# Altium schematic sheet Э3("All Documents",Physical)
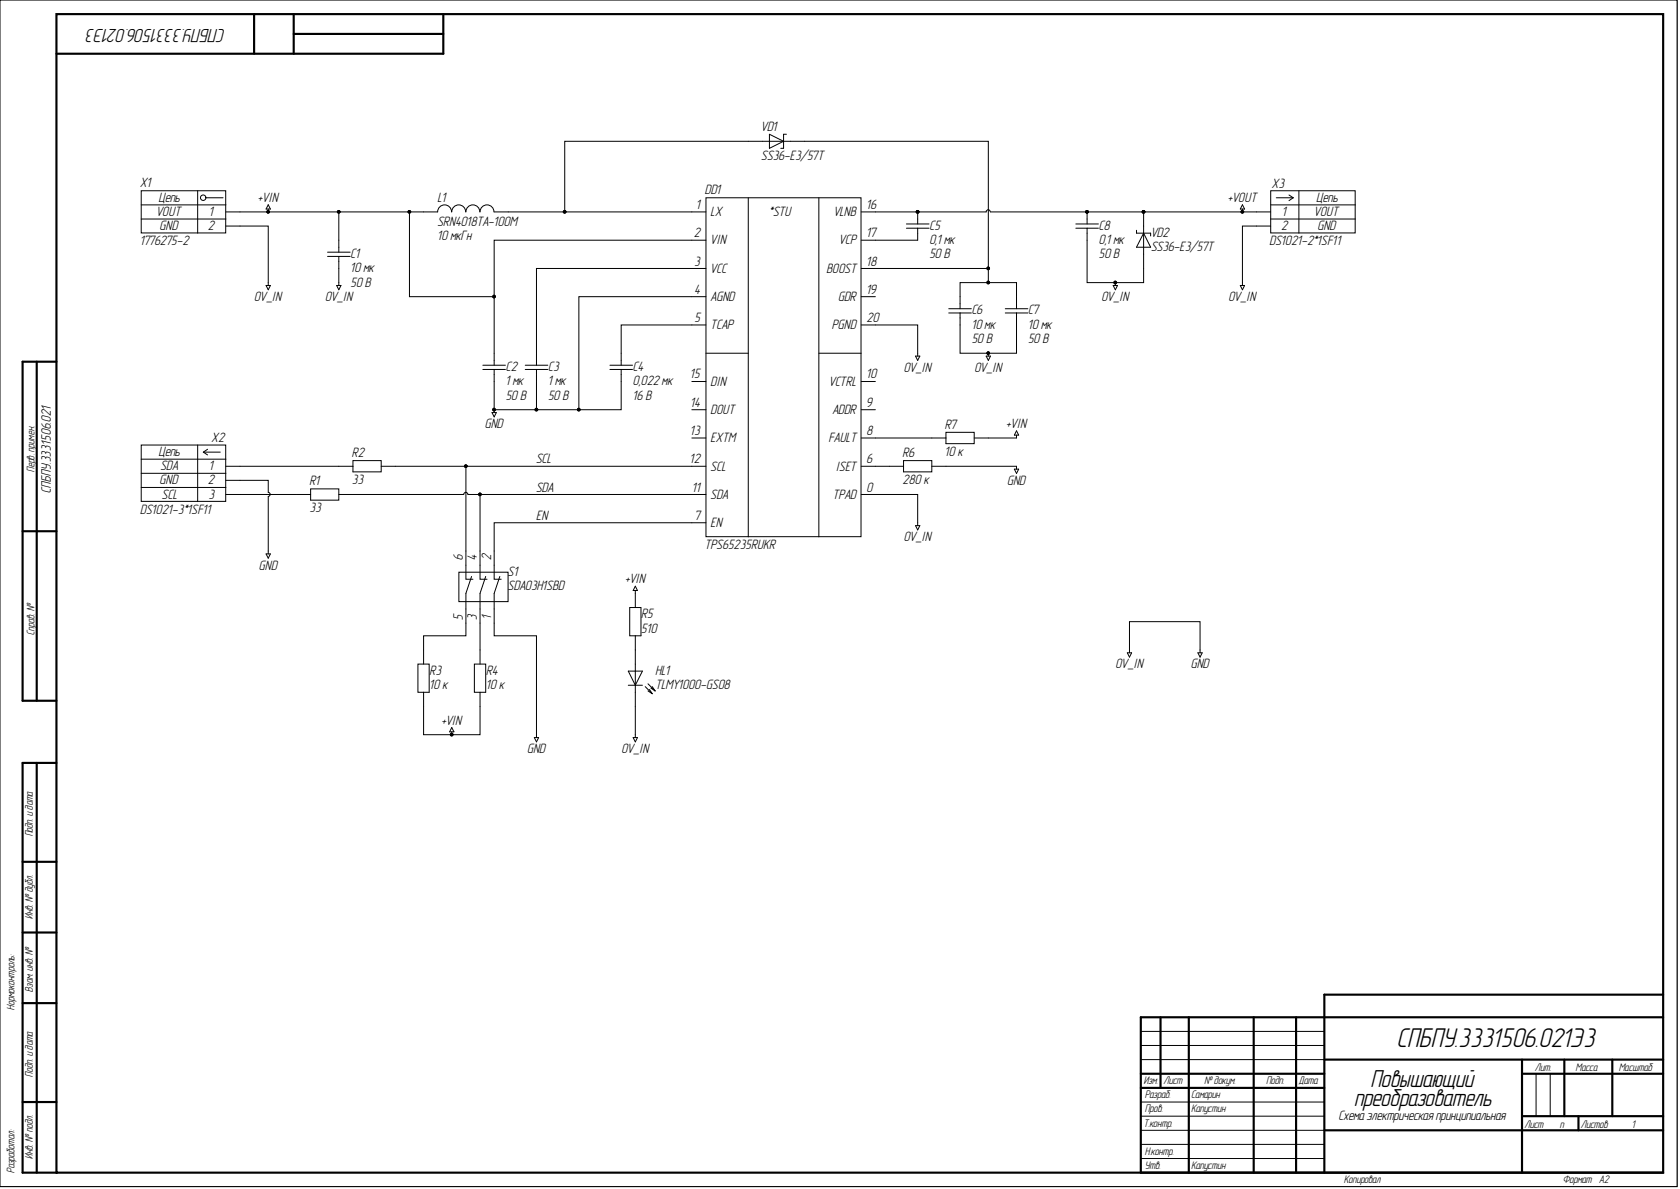
[diagram p0: Э3("All Documents",Physical)]

## Parts
C1  10 мк  Номинальное напряжение: 50 В  [C1206]
C2  1 мк  [C0603]
C3  1 мк  Номинальное напряжение: 50 В  [C0603]
C4  0,022 мк  Номинальное напряжение: 16 В  [C0201]
C5  0,1 мк  Номинальное напряжение: 50 В  [C0603]
C6  10 мк  Номинальное напряжение: 50 В  [C1206]
C7  10 мк  Номинальное напряжение: 50 В  [C1206]
C8  0,1 мк  Номинальное напряжение: 50 В  [C0603]
DD1  TPS65235RUKR  [QFN-20]
HL1  TLMY1000-GS08  [HL0603]
L1  SRN4018TA-100M  Номинал: 10 мкГн  [SRN-2-400X400X180]
R1  33  [R0603]
R2  33  [R0603]
R3  10 к  [R0603]
R4  10 к  [R0603]
R5  510  [R0603]
R6  280 к  [R0603]
R7  10 к  [R0603]
S1  SDA03H1SBD  [DIP-SWITCH-03]
VD1  SS36-E3/57T  [DO-214AB]
VD2  SS36-E3/57T  [DO-214AB]
X1  1776275-2  Contact_2: GND  Contact_1: VOUT  [1776275-2]
X2  DS1021-3*1SF11  Contact_3: SCL  Contact_2: GND  Contact_1: SDA  [pls-2,54-3]
X3  DS1021-2*1SF11  Contact_2: GND  Contact_1: VOUT  [pls-2,54]

## Nets
0V_IN: C1.2 C2.2 C3.2 C4.2 C6.2 C7.2 C8.2 DD1.0(TPAD) DD1.4(AGND) DD1.20(PGND) HL1.1 R6.2 S1.1 VD2.2 X1.2 X2.2 X3.2
+VIN: C1.1 C2.1 DD1.2(VIN) L1.1 R3.1 R4.1 R5.2 R7.2 X1.1
+VOUT: C5.1 C8.1 DD1.16(VLNB) VD2.1 X3.1
EN: DD1.7(EN) S1.2
SCL: DD1.12(SCL) R2.2 S1.6
SDA: DD1.11(SDA) R1.2 S1.4
NetC3_1: C3.1 DD1.3(VCC)
NetC4_1: C4.1 DD1.5(TCAP)
NetC5_2: C5.2 DD1.17(VCP)
NetC6_1: C6.1 C7.1 DD1.18(BOOST) VD1.1
NetDD1_1: DD1.1(LX) L1.2 VD1.2
NetDD1_6: DD1.6(ISET) R6.1
NetDD1_8: DD1.8(FAULT) R7.1
NetDD1_9: DD1.9(ADDR)
NetDD1_10: DD1.10(VCTRL)
NetDD1_13: DD1.13(EXTM)
NetDD1_14: DD1.14(DOUT)
NetDD1_15: DD1.15(DIN)
NetDD1_19: DD1.19(GDR)
NetHL1_2: HL1.2 R5.1
NetR1_1: R1.1 X2.3
NetR2_1: R2.1 X2.1
NetR3_2: R3.2 S1.5
NetR4_2: R4.2 S1.3

## Library data of the parts
C1 C6 C7 = c0094: =Описание; Фамилия: Самарин; Температурный коэффициент емкости: X5R; Рабочие температуры: от –55 °С до +85 °С; Производитель: YAGEO Corp.; Отклонение: ±10 %; Описание: Конденсатор чип 1206 10 мкФ 50 В ±10 %; Наименование: CC1206KKX5R9BB106; Корпус: 1206; Database Table Name: Конденсаторы$; Номинал: 10 мк; Напряжение: 50 В; ID: c0094; HelpURL: https://www.yageo.com/upload/media/product/productsearch/datasheet/mlcc/UPY-GPHC_X5R_4V-to-50V_26.pdf; Description: Конденсатор чип 1206 10 мкФ 50 В ±10 %; Component Kind: Standard; Library Name: Database_Students.DbLib
C2 = c0023: =Описание; Фамилия: Самарин; Рабочие температуры: от -55 °С до +125 °С; Производитель: YAGEO Corp.; Отклонение: ±10 %; Описание: Конденсатор чип 0603 1 мкФ 50 В ±10 %; Номинальное напряжение: 50 В; Наименование: CC0603KPX5R9BB105; Корпус: 0603; Database Table Name: Конденсаторы$; Номинал: 1 мк; Напряжение: 50 В; ID: c0023; HelpURL: https://www.yageo.com/upload/media/product/productsearch/datasheet/mlcc/UPY-GPHC_X5R_4V-to-50V_26.pdf; Description: Конденсатор чип 0603 1 мкФ 50 В ±10 %; Component Kind: Standard; Library Name: Database_Students.DbLib
C3 = c0023: =Описание; Фамилия: Самарин; Рабочие температуры: от -55 °С до +125 °С; Производитель: YAGEO Corp.; Отклонение: ±10 %; Описание: Конденсатор чип 0603 1 мкФ 50 В ±10 %; Наименование: CC0603KPX5R9BB105; Корпус: 0603; Database Table Name: Конденсаторы$; Номинал: 1 мк; Напряжение: 50 В; ID: c0023; HelpURL: https://www.yageo.com/upload/media/product/productsearch/datasheet/mlcc/UPY-GPHC_X5R_4V-to-50V_26.pdf; Description: Конденсатор чип 0603 1 мкФ 50 В ±10 %; Component Kind: Standard; Library Name: Database_Students.DbLib
C4 = c0015: =Описание; Фамилия: Жернаков; Рабочие температуры: от -55 °С до +85 °С; Производитель: YAGEO Corp.; Отклонение: ±10 %; Описание: Конденсатор чип 0201 0,022 мкФ 16 В ±10 %; Наименование: CC0201KRX5R7BB223; Корпус: 0201; Database Table Name: Конденсаторы$; Номинал: 0,022 мк; Напряжение: 16 В; ID: c0015; HelpURL: https://www.yageo.com/upload/media/product/productsearch/datasheet/mlcc/UPY-GPHC_X5R_4V-to-50V_26.pdf; Description: Конденсатор чип 0201 0,022 мкФ 16 В ±10 %; Component Kind: Standard; Library Name: Database_Students.DbLib
C5 C8 = c0018: =Описание; Фамилия: Ларионов; Рабочие температуры: от -55 °С до +125 °С; Производитель: YAGEO Corp.; Отклонение: ±5 %; Описание: Конденсатор чип 0603 0,1 мкФ 50 В ±5 %; Наименование: CC0603JRX7R9BB104; Корпус: 0603; Database Table Name: Конденсаторы$; Номинал: 0,1 мк; Напряжение: 50 В; ID: c0018; HelpURL: https://www.yageo.com/upload/media/product/products/datasheet/mlcc/UPY-GPHC_X7R_6.3V-to-50V_20.pdf; Description: Конденсатор чип 0603 0,1 мкФ 50 В ±5 %; Component Kind: Standard; Library Name: Database_Students.DbLib
DD1 = dd0005: =Описание; Частота переключения: до 1 МГц; Фамилия: Самарин; Рабочие температуры: от -40 °С до +85 °С; Производитель: Texas Instruments; Описание: Повышающий преобразователь напряжения 20 В и 3 А; Коммутируемый ток: до 1 А; Выходное напряжение: от 11 В до 20 В; Входное напряжение: от 4,5 В до 20 В; Database Table Name: Преобразователи напряжения$; Наименование: TPS65235RUKR; Корпус: QFN-20; ID: dd0005; HelpURL: https://www.ti.com/product/TPS65235; Description: Повышающий преобразователь напряжения 20 В и 3 А; Component Kind: Standard; Library Name: Database_Students.DbLib
HL1 = hl0003: =Описание; Цвет: Yellow; Фамилия: Ларионов; Рассеиваемая мощность: 40 мВт; Рабочие температуры: от -40 °С до +100 °С; Производитель: Vishay Intertechnology, Inc.; Описание: Светодиод чип 0603 желтый; Номинальный прямой ток: 15 мА; Номинальное падение напряжения: 1,8 В; Номинальная сила света: 7,5 мкд; Номинальная длина волны: 588 нм; Корпус: 0603; Database Table Name: Светодиоды$; Наименование: TLMY1000-GS08; ID: hl0003; HelpURL: https://www.vishay.com/leds/list/product-83172/; Description: Светодиод чип 0603 желтый; Component Kind: Standard; Library Name: Database_Students.DbLib
L1 = l0003: =Описание; Фамилия: Самарин; Ток насыщения: 1,5 А; Резонансная частота: 35 МГц; Рабочие температуры: от –55 °С до +125 °С; Рабочее напряжение: не указано; Производитель: Bourns, Inc.; Отклонение: ±20 %; Описание: Катушка индуктивности 10 мкГн ±20 %; Номинальное активное сопротивление: 150 мОм; Корпус: 4,0 x 4,0 x 1,8; Database Table Name: Катушки индуктивности$; Номинальный ток (при нагреве на 20 °С/40 °С): 1,5 А; Наименование: SRN4018TA-100M; Максимальное активное сопротивление: не указано; Индуктивность: 10 мкГн; ID: l0003; HelpURL: https://www.bourns.com/products/magnetic-products/power-inductors-aec-q200-compliant/product/SRN4018TA; Description: Катушка индуктивности 10 мкГн ±20 %; Component Kind: Standard; Library Name: Database_Students.DbLib
R1 R2 = r0005: =Описание; Фамилия: Козлов; Рабочие температуры: от -55 °С до +155 °С; Производитель: YAGEO Corp.; Отклонение: ±1 %; Описание: Резистор чип 0603 33 Ом ±1 % 0,1 Вт; Номинальный ток: 1 А; Номинальное напряжение: 75 В; Наименование: AA0603FR-0733RL; Корпус: 0603; Database Table Name: Резисторы$; Номинал: 33; ID: r0005; HelpURL: https://www.yageo.com/upload/media/product/productsearch/datasheet/rchip/PYu-AA_51_RoHS_L_3.pdf; Description: Резистор чип 0603 33 Ом ±1 % 0,1 Вт; Component Kind: Standard; Library Name: Database_Students.DbLib
R3 R4 R7 = r0022: =Описание; Фамилия: Самарин; Рабочие температуры: от -55 °С до +155 °С; Производитель: YAGEO Corp.; Отклонение: ±1 %; Описание: Резистор чип 0603 10 кОм ±1 % 0,1 Вт; Номинальный ток: 1 А; Номинальное напряжение: 75 В; Наименование: RC0603FR-0710KL; Корпус: 0603; Database Table Name: Резисторы$; Номинал: 10 к; ID: r0022; HelpURL: https://www.yageo.com/upload/media/product/productsearch/datasheet/rchip/PYu-RC_Group_51_RoHS_L_11.pdf; Description: Резистор чип 0603 10 кОм ±1 % 0,1 Вт; Component Kind: Standard; Library Name: Database_Students.DbLib
R5 = r0011: =Описание; Фамилия: Самарин; Рабочие температуры: от -55 °С до +155 °С; Производитель: YAGEO Corp.; Отклонение: ±1 %; Описание: Резистор чип 0603 510 Ом ±1 % 0,1 Вт; Номинальный ток: 1 А; Номинальное напряжение: 75 В; Наименование: RC0603FR-07510RL; Корпус: 0603; Database Table Name: Резисторы$; Номинал: 510; ID: r0011; HelpURL: https://www.yageo.com/upload/media/product/productsearch/datasheet/rchip/PYu-RC_Group_51_RoHS_L_11.pdf; Description: Резистор чип 0603 510 Ом ±1 % 0,1 Вт; Component Kind: Standard; Library Name: Database_Students.DbLib
R6 = r0040: =Описание; Фамилия: Паньков; Рабочие температуры: от -55 °С до +155 °С; Производитель: YAGEO Corp.; Отклонение: ±1 %; Описание: Резистор чип 0603 280 кОм ±1 % 0,1 Вт; Номинальный ток: 1 А; Номинальное напряжение: 75 В; Наименование: RC0603FR-07280KL; Корпус: 0603; Database Table Name: Резисторы$; Номинал: 280 к; ID: r0040; HelpURL: https://www.yageo.com/upload/media/product/productsearch/datasheet/rchip/PYu-RC_Group_51_RoHS_L_11.pdf; Description: Резистор чип 0603 280 кОм ±1 % 0,1 Вт; Component Kind: Standard; Library Name: Database_Students.DbLib
S1 = sw0003: =Описание; Фамилия: Самарин; Ток нагрузки: 100 мА @ 5 В; Сопротивление контакта: 50 мОм; Рабочие температуры: от -40 °С до +85 °С; Промывка: Разрешена; Производитель: C&K; Описание: 3-х позиционный движковый переключатель; Корпус: 7,06х9,30х5,45; Количество контактов: 3; Database Table Name: Переключатели$; Наименование: SDA03H1SBD; ID: sw0003; HelpURL: https://www.ckswitches.com/products/switches/product-details/DIP/SDA/SDA02H1SBD/; Description: 3-х позиционный движковый переключатель; Component Kind: Standard; Library Name: Database_Students.DbLib
VD1 VD2 = vd0004: =Описание; Фамилия: Жернаков; Рабочие температуры: от –55 °С до +150 °С; Производитель: Vishay Intertechnology, Inc.; Пиковое прямое напряжение: 0,75 В; Описание: Диод Шоттки 60 В 3 А; Макс допустимый средний прямой ток: 3 А; Импульсное обратное напряжение: 60 В; Database Table Name: Диоды$; Наименование: SS36-E3/57T; Корпус: DO-214AB; ID: vd0004; HelpURL: https://www.vishay.com/product?docid=88751; Description: Диод Шоттки 60 В 3 А; Component Kind: Standard; Library Name: Database_Students.DbLib
X1 = x0005: =Описание; Фамилия: Паньков; Производитель: TE Connectivity; Описание: Клеммная колодка 2 pin 3,5 мм; Database Table Name: Разъемы$; Наименование: 1776275-2; ID: x0005; HelpURL: https://www.te.com/usa-en/product-1776275-2.html; Description: Клеммная колодка 2 pin 3,5 мм; Component Kind: Standard; Library Name: Database_Students.DbLib
X2 = HEADER-3_PLG: Library Name: header-3_plg.SchLib; Component Kind: Standard
X3 = HEADER-2_PLG: Library Name: header-2_plg.SchLib; Component Kind: Standard
